# Altium schematic sheet Shield_conn.SchDoc
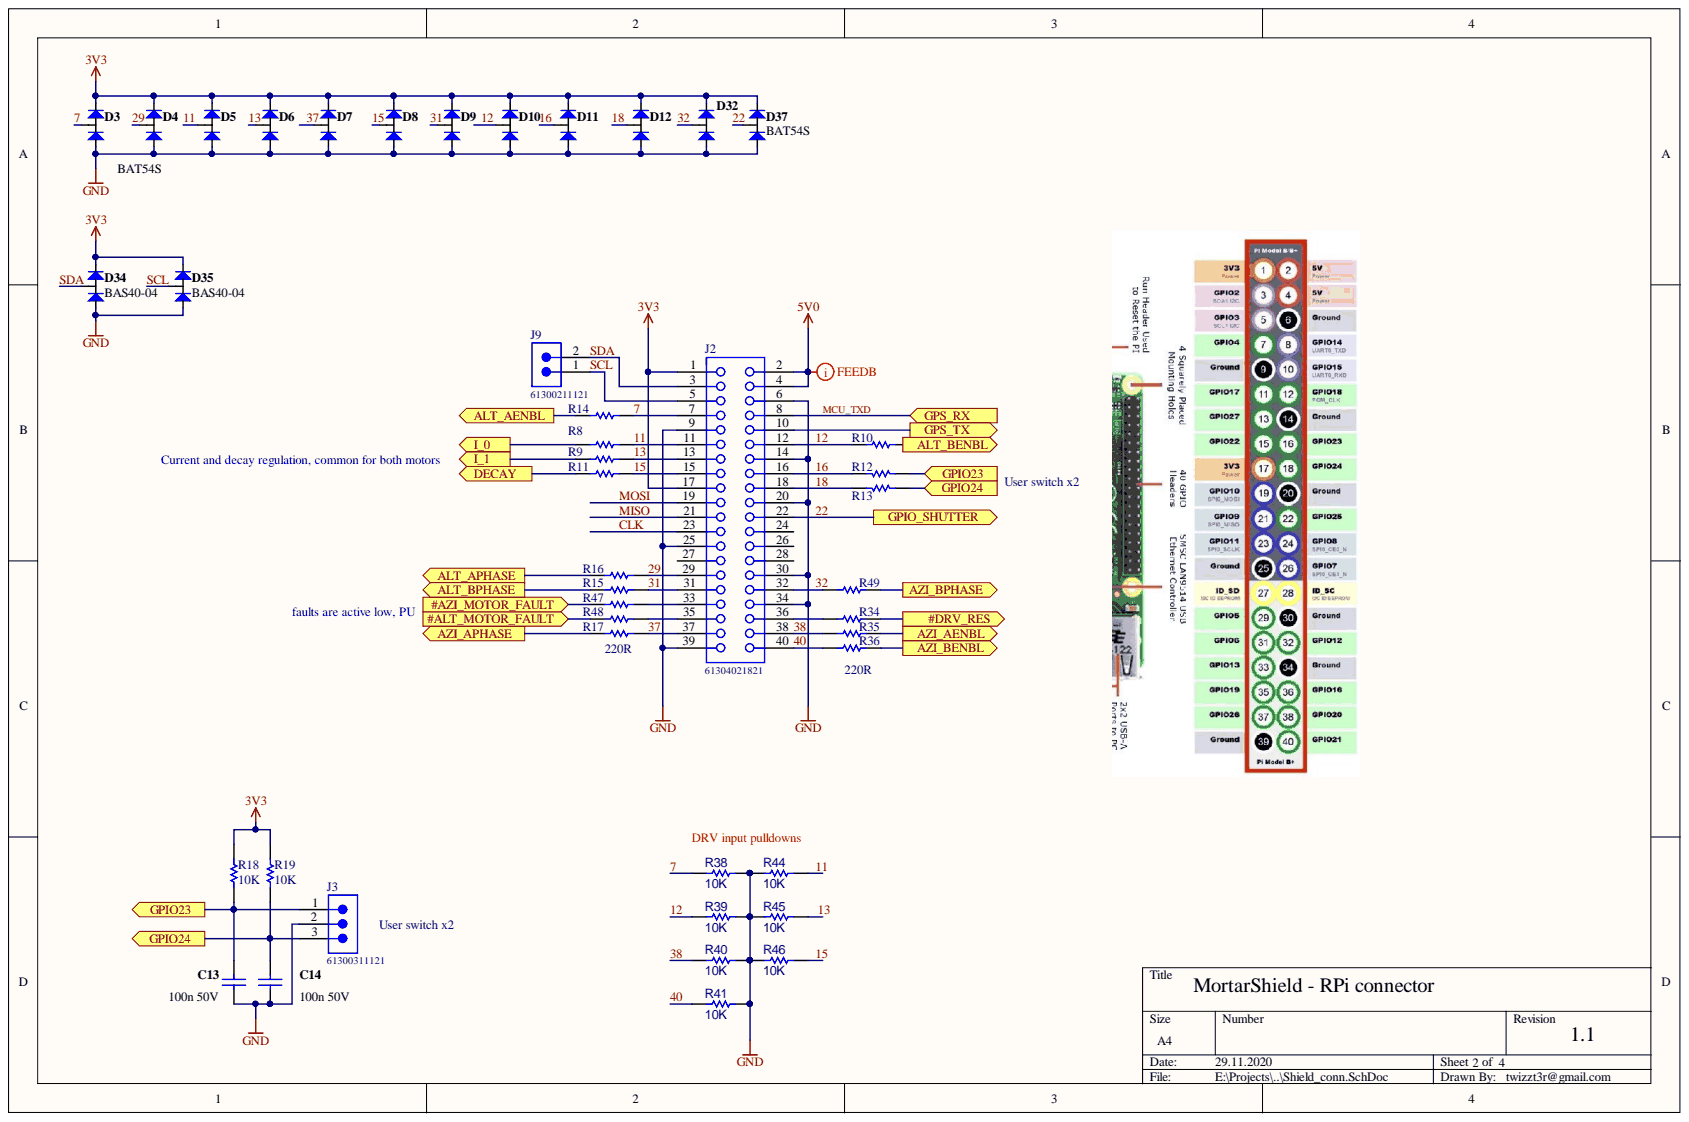
[diagram p0: Shield_conn.SchDoc]

## Parts
C13  value 100n 50V  [C_0603]  (hidden comment: C_100n_25V_0603)
C14  value 100n 50V  [C_0603]  (hidden comment: C_100n_25V_0603)
D3  BAT54S  [SOT23]
D4  BAT54S  [SOT23]
D5  BAT54S  [SOT23]  (value not drawn)
D6  BAT54S  [SOT23]  (value not drawn)
D7  BAT54S  [SOT23]  (value not drawn)
D8  BAT54S  [SOT23]  (value not drawn)
D9  BAT54S  [SOT23]  (value not drawn)
D10  BAT54S  [SOT23]  (value not drawn)
D11  BAT54S  [SOT23]  (value not drawn)
D12  BAT54S  [SOT23]  (value not drawn)
D32  BAT54S  [SOT23]  (value not drawn)
D34  BAS40-04  [SOT23]
D35  BAS40-04  [SOT23]
D37  BAT54S  [SOT23]
J2  61304021821  [RPI_SHIELD_CONN_BOT]
J3  61300311121  [61300311121]
J9  61300211121  [61300211121]
R8  270R  [R_0603]  (value not drawn)
R9  270R  [R_0603]  (value not drawn)
R10  270R  [R_0603]  (value not drawn)
R11  270R  [R_0603]  (value not drawn)
R12  270R  [R_0603]  (value not drawn)
R13  270R  [R_0603]  (value not drawn)
R14  270R  [R_0603]  (value not drawn)
R15  270R  [R_0603]  (value not drawn)
R16  270R  [R_0603]  (value not drawn)
R17  220R  [R_0603]
R18  10K  [R_0603]
R19  10K  [R_0603]
R34  270R  [R_0603]  (value not drawn)
R35  270R  [R_0603]  (value not drawn)
R36  270R  [R_0603]  (value not drawn)
R38  VALUE_TXT: 10K  [R_0603]  (hidden comment: R_10K_0603)
R39  VALUE_TXT: 10K  [R_0603]  (hidden comment: R_10K_0603)
R40  VALUE_TXT: 10K  [R_0603]  (hidden comment: R_10K_0603)
R41  VALUE_TXT: 10K  [R_0603]  (hidden comment: R_10K_0603)
R44  VALUE_TXT: 10K  [R_0603]  (hidden comment: R_10K_0603)
R45  VALUE_TXT: 10K  [R_0603]  (hidden comment: R_10K_0603)
R46  VALUE_TXT: 10K  [R_0603]  (hidden comment: R_10K_0603)
R47  270R  [R_0603]  (value not drawn)
R48  270R  [R_0603]  (value not drawn)
R49  220R  [R_0603]  (value not drawn)

## Ports
#ALT_MOTOR_FAULT, #AZI_MOTOR_FAULT, #DRV_RES, ALT_AENBL, ALT_APHASE, ALT_BENBL, ALT_BPHASE, AZI_AENBL, AZI_APHASE, AZI_BENBL, AZI_BPHASE, DECAY, GPIO23, GPIO24, GPIO_SHUTTER, GPS_RX, GPS_TX, I_0, I_1

## Nets
3V3: D3.2 D4.2 D5.2 D6.2 D7.2 D8.2 D9.2 D10.2 D11.2 D12.2 D32.2 D34.2 D35.2 D37.2 J2.1 J2.17 R18.2 R19.2
5V0: J2.2 J2.4
GND: C13.2 C14.2 D3.1 D4.1 D5.1 D6.1 D7.1 D8.1 D9.1 D10.1 D11.1 D12.1 D32.1 D34.1 D35.1 D37.1 J2.6 J2.9 J2.14 J2.20 J2.25 J2.30 J2.34 J2.39 J3.2 R38.1 R39.1 R40.1 R41.1 R44.1 R45.1 R46.1
7: D3.3 J2.7 R14.2 R38.2
11: D5.3 J2.11 R8.2 R44.2
12: D10.3 J2.12 R10.1 R39.2
13: D6.3 J2.13 R9.2 R45.2
15: D8.3 J2.15 R11.2 R46.2
16: D11.3 J2.16 R12.2
18: D12.3 J2.18 R13.2
22: D37.3 J2.22 ; port GPIO_SHUTTER
29: D4.3 J2.29 R16.2
31: D9.3 J2.31 R15.1
32: D32.3 J2.32 R49.1
37: D7.3 J2.37 R17.2
38: J2.38 R35.1 R40.2
40: J2.40 R36.1 R41.2
#ALT_MOTOR_FAULT: R48.1 ; port #ALT_MOTOR_FAULT
#AZI_MOTOR_FAULT: R47.1 ; port #AZI_MOTOR_FAULT
#DRV_RES: R34.1 ; port #DRV_RES
ALT_AENBL: R14.1 ; port ALT_AENBL
ALT_APHASE: R16.1 ; port ALT_APHASE
ALT_BENBL: R10.2 ; port ALT_BENBL
ALT_BPHASE: R15.2 ; port ALT_BPHASE
AZI_AENBL: R35.2 ; port AZI_AENBL
AZI_APHASE: R17.1 ; port AZI_APHASE
AZI_BENBL: R36.2 ; port AZI_BENBL
AZI_BPHASE: R49.2 ; port AZI_BPHASE
CLK: J2.23
DECAY: R11.1 ; port DECAY
GPIO23: C13.1 J3.1 R12.1 R18.1 ; port GPIO23
GPIO24: C14.1 J3.3 R13.1 R19.1 ; port GPIO24
GPS_TX: J2.10 ; port GPS_TX
I_0: R8.1 ; port I_0
I_1: R9.1 ; port I_1
MCU_TXD: J2.8 ; port GPS_RX
MISO: J2.21
MOSI: J2.19
SCL: D35.3 J2.5 J9.1
SDA: D34.3 J2.3 J9.2
NetJ2_33: J2.33 R47.2
NetJ2_35: J2.35 R48.2
NetJ2_36: J2.36 R34.2

Not connected: J2 24 26 27 28

## Library data of the parts
C13 C14 = C_100n_25V_0603: 100n 25V 0603 X7R; mfg_pn: 885012206071; Component Kind: Standard; Library Name: Kayon_01.SCHLIB
D3-D12 D32 D37 = BAT54S: Diode; mfg_pn: BAT54SQ-7-F; Component Kind: Standard; Library Name: SCH.SchLib; link: https://pl.mouser.com/ProductDetail/Diodes-Incorporated/BAT54SQ-7-F?qs=sGAEpiMZZMtQ8nqTKtFS%2FCxNu2bLpS4AvuFrtQozqiHJPZeL9QFxYQ%3D%3D
D34 D35 = BAT54S: Diode; Component Kind: Standard; Library Name: SCH.SchLib; mfg_pn: BAS40-04
J2 = 61304021821: WR-PHD Socket Header, THT, pitch 2.54mm, Dual Row, Vertical, 40p; Manufacturer: Wurth Elektronik; Contact Resistance: 20mOhm; Pin Number: 40; Rated Current: 3A; Withstanding Voltage: 500VAC; Working Voltage: 250VAC; Component Kind: Standard; ComponentLink1Description: Manufacturer; ComponentLink1URL: http://www.we-online.de; ComponentLink2Description: Datasheet; ComponentLink2URL: https://www.we-online.com/catalog/datasheet/61304021821.pdf; Gender: Socket Header; Library Name: SCH.SchLib; Manufacturer Part Number: 61304021821; Mounting Technology: THT; Operating Temperature: -40 to +125degC; Orientation: Vertical; Packaging: Tray; Pitch: 2.54mm; Published Date: May 2020; Row Number: Dual
J3 = 61300311121: WR-PHD Pin Header, THT, pitch 2.54mm, Single Row, Vertical, 3pin; Contact Resistance: 20mOhm; Gender: Male; Pin Number: 3; Rated Current: 3A; Withstanding Voltage: 500VAC; Working Voltage: 250VAC; Component Kind: Standard; ComponentLink1Description: Manufacturer; ComponentLink1URL: http://www.we-online.de; ComponentLink2Description: Datasheet; ComponentLink2URL: https://katalog.we-online.de/em/datasheet/6130xx11121.pdf; Library Name: SCH.SchLib; mfg_pn: 61300311121; Mounting Technology: THT; Operating Temperature: -40 to +105degC; Orientation: Vertical; Packaging: Bag; Pitch: 2.54mm; Published Date: June 2019; Row Number: Single; Publisher: Wurth Elektronik
J9 = 61300211121: WR-PHD Pin Header, THT, pitch 2.54mm, Single Row, Vertical, 2p; Manufacturer: Wurth Elektronik; Contact Resistance: 20mOhm; Pin Number: 2; Rated Current: 3A; Withstanding Voltage: 500VAC; Working Voltage: 250VAC; Component Kind: Standard; ComponentLink1Description: Manufacturer; ComponentLink1URL: http://www.we-online.de; ComponentLink2Description: Datasheet; ComponentLink2URL: https://www.we-online.com/catalog/datasheet/61300211121.pdf; Gender: Pin Header; Library Name: SCH.SchLib; Manufacturer Part Number: 61300211121; Mounting Technology: THT; Operating Temperature: -40 to +105degC; Orientation: Vertical; Packaging: Bag; Pitch: 2.54mm; Published Date: May 2020; Row Number: Single
R8-R19 R34-R36 R47-R49 = R_0603: Component Kind: Standard; Library Name: SCH.SchLib
R38-R41 R44-R46 = R_10K_0603: SMD RES 10K-5%-1/10W; Manufacturer: YAGEO; Component Kind: Standard; Library Name: SCH.SchLib; Package: 0603
